# Altium schematic sheet MAIN_MCU_LORA.SchDoc(MAIN_MCU_LORA)
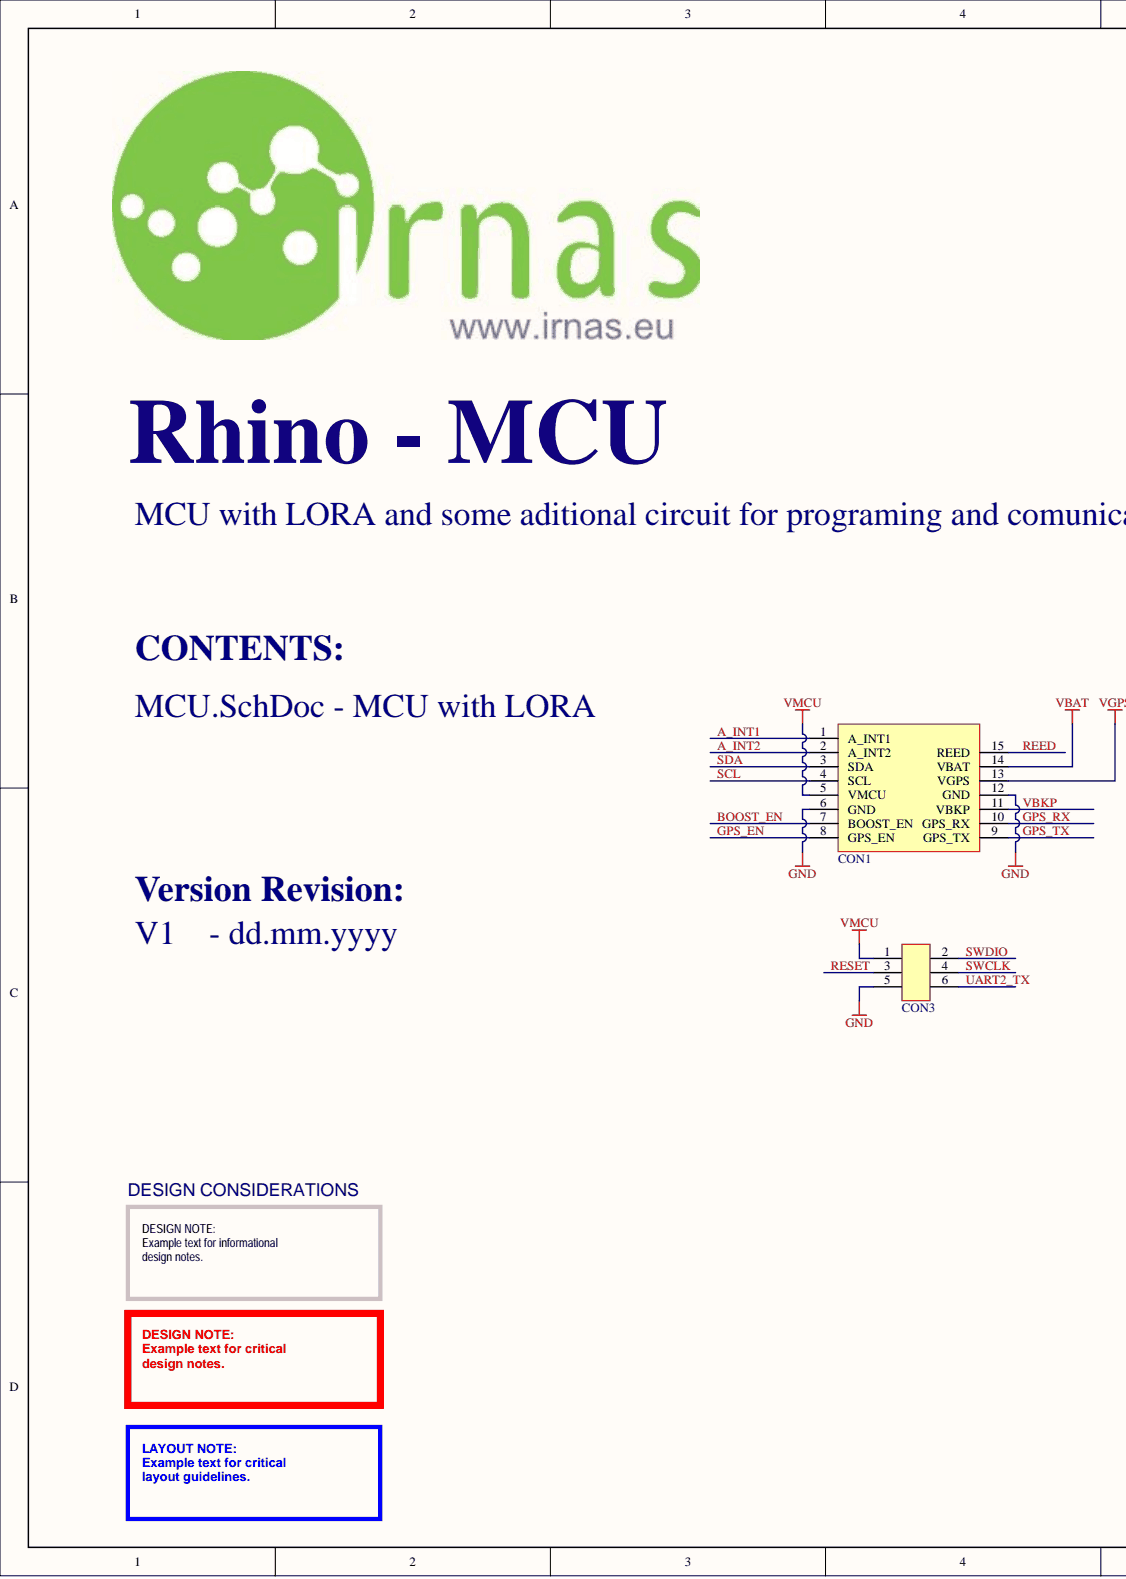
[diagram p0: MAIN_MCU_LORA.SchDoc, left]
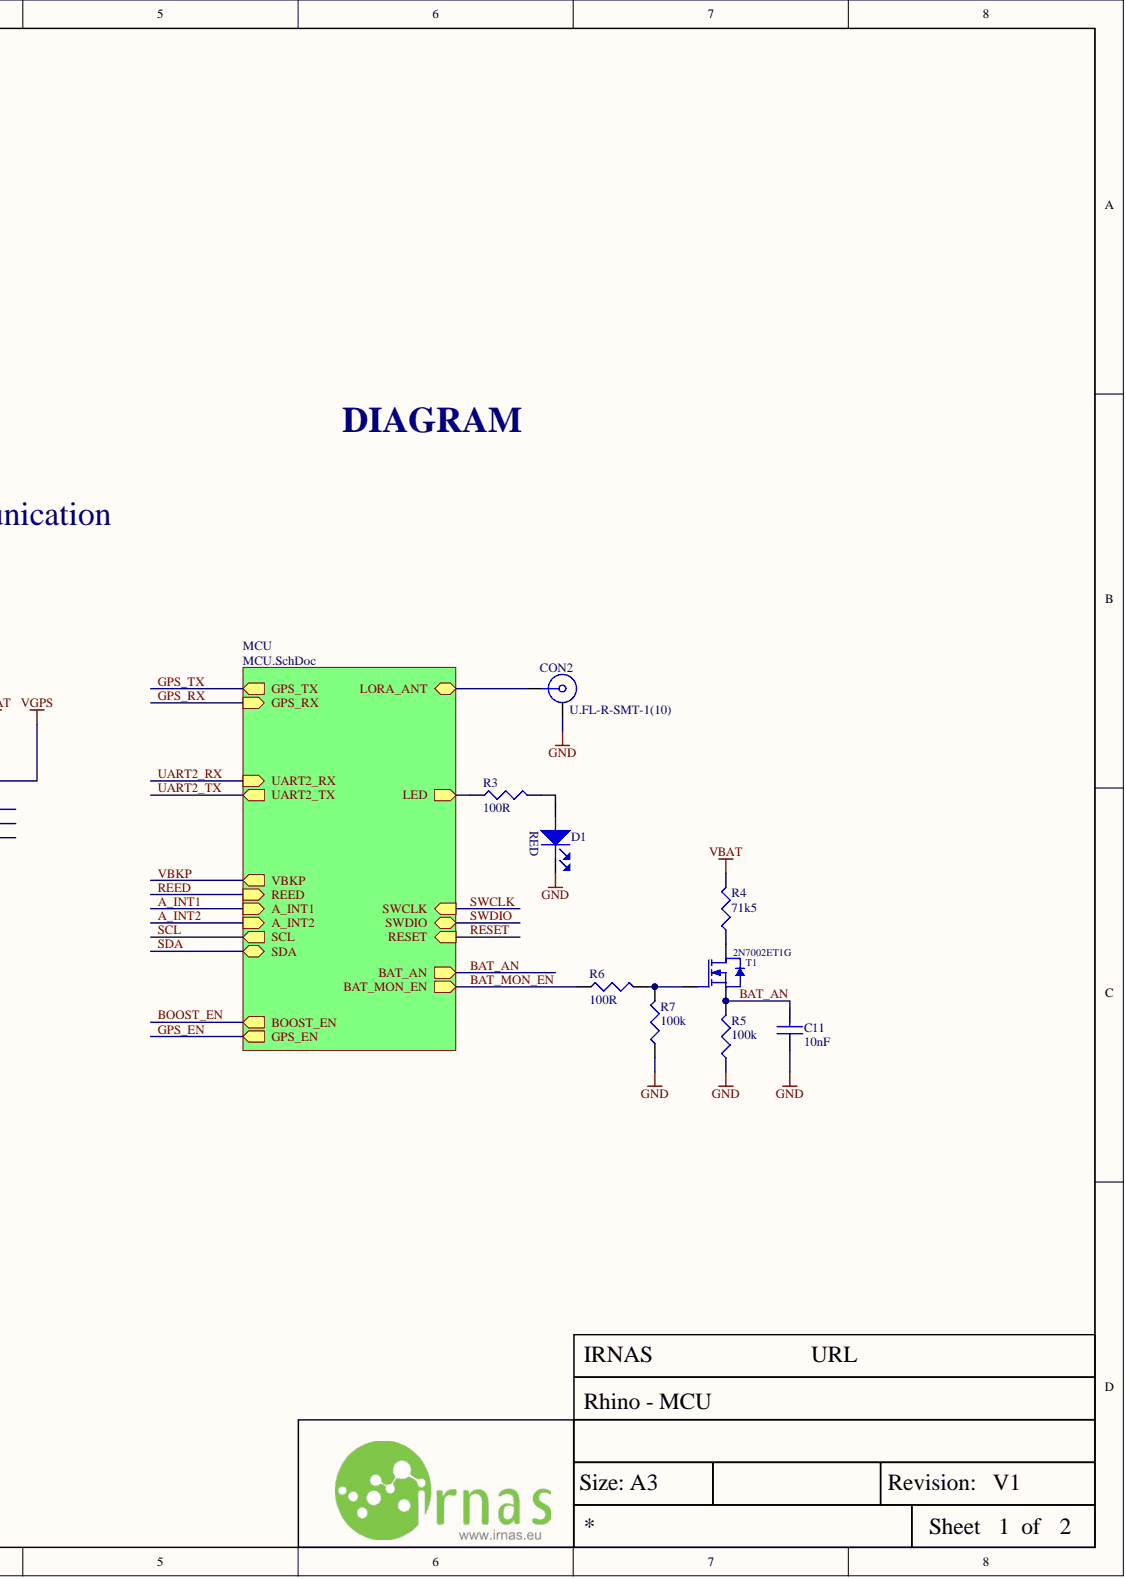
[diagram p1: MAIN_MCU_LORA.SchDoc, right]

## Parts
C11  10nF  [CAP0402]
CON1  Between PCB connection  [SmartParks_Rhino]  (value not drawn)
CON2  U.FL-R-SMT-1(10)  [ufl connector smd]
CON3  Tag connect  [TC2030-IDC-NL]  (value not drawn)  (no BOM)
D1  LED RED  [LED0603]  (value not drawn)
R3  100R  [RES0402]
R4  71k5  [RES0402]
R5  100k  [RES0402]
R6  100R  [RES0402]
R7  100k  [RES0402]
T1  2N7002ET1G  [SOT23-3AL]

## Nets
GND: C11.1 CON1.6(GND) CON1.12(GND) CON2.2 CON3.5 D1.1 R5.1 R7.1
VBAT: CON1.14(VBAT) R4.2
VGPS: CON1.13(VGPS)
VMCU: CON1.5(VMCU) CON3.1
A_INT1: CON1.1(A_INT1)
A_INT2: CON1.2(A_INT2)
BAT_AN: C11.2 R5.2 T1.2
BAT_MON_EN: R6.1
BOOST_EN: CON1.7(BOOST_EN)
GPS_EN: CON1.8(GPS_EN)
GPS_RX: CON1.10(GPS_RX)
GPS_TX: CON1.9(GPS_TX)
REED: CON1.15(REED)
RESET: CON3.3
SCL: CON1.4(SCL)
SDA: CON1.3(SDA)
SWCLK: CON3.4
SWDIO: CON3.2
UART2_TX: CON3.6
VBKP: CON1.11(VBKP)
NetCON2_1: CON2.1
NetD1_2: D1.2 R3.2
NetR3_1: R3.1
NetR4_1: R4.1 T1.3
NetR6_2: R6.2 R7.2 T1.1
UART2_RX:

## Library data of the parts
C11 = C - 10nF/0402: CAP CER 10000PF 16V X7R 0402; Manufacturer Part Number: GRM155R71C103KA01D; Manufacturer: Murata; IRNAS Part No.: /; Tolerance: 10%; Width: 0.02inch; Voltage Rating (DC): 16V; Voltage Rating: 16V; Voltage: 16V; Thickness: 0.022inch; Termination: SMD/SMT; Supplier Part Number 1: 1828864; Supplier 1: Farnell; Stock 1: 16478; REACH SVHC: No SVHC; Radiation Hardening: No; Pricing 1: 10=0.0216, 100=0.0151, 500=0.0104, 2500=0.0069, 5000=0.0056 (EUR); Packaging: Tape and Reel; Package Quantity: 1; Number of Pins: 2; Mount: Surface Mount; Min Operating Temperature: -55°C; Max Operating Temperature: 125°C; Library Name: Passive.SchLib; Length: 1mm; Lead Free: Lead Free; Height: 0.5mm; Dielectric: X7R; Depth: 0.5mm; ComponentLink6URL: https://datasheet.ciiva.com/7937/608985-7937614.pdf; ComponentLink6Description: https://datasheet.ciiva.com/7937/608985-7937614.pdf; ComponentLink5URL: https://datasheet.ciiva.com/22698/608985-22698415.pdf; ComponentLink5Description: https://datasheet.ciiva.com/22698/608985-22698415.pdf; ComponentLink4URL: https://datasheet.ciiva.com/18184/getdatasheetpartid-178863-18184649.pdf; ComponentLink4Description: https://datasheet.ciiva.com/18184/getdatasheetpartid-178863-18184649.pdf; ComponentLink3URL: https://datasheet.ciiva.com/129/product-129776.pdf; ComponentLink3Description: https://datasheet.ciiva.com/129/product-129776.pdf; ComponentLink2URL: https://datasheet.ciiva.com/129/partnumbering-e-01-129775.pdf; ComponentLink2Description: https://datasheet.ciiva.com/129/partnumbering-e-01-129775.pdf; ComponentLink1URL: https://datasheet.ciiva.com/129/partnumbering-e-01-129774.pdf; ComponentLink1Description: https://datasheet.ciiva.com/129/partnumbering-e-01-129774.pdf; Component Kind: Standard; Case Code (Metric): 1005; Case Code (Imperial): 0402; Case/Package: 0402; Capacitance: 10nF
CON1 = SmartParks_Rhino: Library Name: Connectors.SchLib; Description: Between PCB connection; Component Kind: Standard
CON2 = U.FL-R-SMT-1(10): RF / Coaxial Connector, U.FL Coaxial, Straight Jack, Surface Mount Vertical, 50 ohm, Brass; Manufacturer: Hirose; Shield Termination: Solder; Other Names: H11891TR  Q5400621  U.FL-R-SMT-1 10  U.FL-R-SMT-1(10)-ND  UFLRSMT110; Online Catalog: UMCC (Ultra Miniature Coax Connectors), U.FL Series; Impedance: 50 Ohm; Frequency - Max: 6GHz; Featured Product: U.FL Series Connectors; Dielectric Material: Liquid Crystal Polymer (LCP); Connector Type: Receptacle, Male Pin; Connector Style: U.FL, Ultra Miniature Coaxial; Center Contact Plating: Gold; Body Material: Phosphor Bronze; Body Finish: Silver; Voltage Rating: -; Supplier Part Number 1: 1688077; Supplier 1: Farnell; Stock 1: 0; Standard Package: 2,500; Series: U.FL; Packaging: Tape & Reel (TR); Operating Temperature: -40°C ~ 90°C; Mounting Type: Surface Mount; Manufacturer Part Number: U.FL-R-SMT-1(10); Library Name: Connectors.SchLib; Ingress Protection: -; Housing Color: Beige; Features: -; Fastening Type: Snap-On; Family: Coaxial Connectors (RF); Contact Termination: Solder; ComponentLink3URL: http://www.farnell.com/datasheets/1947917.pdf; ComponentLink3Description: http://www.farnell.com/datasheets/1947917.pdf; ComponentLink2URL: http://uk.rs-online.com/webdocs/1363/0900766b81363563.pdf; ComponentLink2Description: http://uk.rs-online.com/webdocs/1363/0900766b81363563.pdf; ComponentLink1URL: http://www.farnell.com/datasheets/91524.pdf; ComponentLink1Description: http://www.farnell.com/datasheets/91524.pdf; Component Kind: Standard; Center Contact Material: Brass; Category: Connectors, Interconnects; Cable Group: -
CON3 = tag connecot 6 pin: Library Name: Connectors.SchLib
D1 = LED - LED RED: BROADCOM LIMITED - HSMS-C190 - LED, RED, 10MCD, 626NM; Wavelength Typ: 626; Viewing Angle: 170°; SVHC: No SVHC (17-Dec-2015)<!--FANDB--><div class="features-and-benefits-container"><div class="features-and-benefits-description"><span class="features-benefits-value">The HSMS-C190 is a HER SMD Chip LED with untinted/diffused lens, made of GaP. It is designed in an industry standard package 1.6 x 0.8mm footprint with 0.8mm profile. It is compatible with IR reflow solder processes. The small size and wide viewing angle make this LED prime choices for backlighting applications and front panel illumination especially where space is a premium.</span></div><div class="features-and-benefits-list"><ul class="features-and-benefits-list-list"><li class="features-and-benefits-list-item">Small size</li><li class="features-and-benefits-list-item">Industry standard footprint</li><li class="features-and-benefits-list-item">Compatible with IR solder</li><li class="features-and-benefits-list-item">Diffused optics</li><li class="features-and-benefits-list-item">-40 to 85; Luminous Intensity: 10; Lens Shape: Rectangular; LED Mounting: SMD; Forward Voltage: 2.1; Forward Current If: 20; Supplier Part Number 1: 2497356; Supplier 1: Farnell; Stock 1: 7534; RoHS: YES; Product Range: -; Pricing 1: 1=0.361, 10=0.256, 50=0.235, 100=0.106, 200=0.101 (GBP); Packaging: Cut Tape; MSL: -; Manufacturer Part Number: HSMS-C190; Manufacturer: BROADCOM LIMITED; Library Name: Active.SchLib; LED Colour: Red; ComponentLink3URL: http://www.farnell.com/datasheets/2165483.pdf; ComponentLink3Description: http://www.farnell.com/datasheets/2165483.pdf; ComponentLink2URL: http://www.farnell.com/datasheets/1681524.pdf; ComponentLink2Description: http://www.farnell.com/datasheets/1681524.pdf; ComponentLink1URL: http://www.farnell.com/datasheets/313031.pdf; ComponentLink1Description: http://www.farnell.com/datasheets/313031.pdf; Component Kind: Standard; Bulb Size: -; Automotive Qualification Standard: -
R3 R6 = R - 100R/0402: MULTICOMP         MCMR04X1000FTL            SMD Chip Resistor, Ceramic, MCMR Series, 100 ohm, 50 V, 0402 [1005 Metric], 62.5 mW,  1%; IRNAS Part No.: /; Voltage Rating (DC): 50V; Temperature Coefficient: 100ppm/°C; Power Rating: 62.5mW; Voltage Rating: 50V; Tolerance: 1%; Supplier Part Number 1: 2072515; Supplier 1: Farnell; Stock 1: 62365; Resistance: 100R; REACH SVHC: No SVHC; Pricing 1: 10=0.0071, 100=0.0042, 500=0.003, 2500=0.0024, 5000=0.0019 (GBP); Packaging: Cut Tape; Manufacturer Part Number: MCMR04X1000FTL; Manufacturer: Multicomp; Library Name: Passive.SchLib; Composition: Ceramic; ComponentLink2URL: https://datasheet.ciiva.com/22708/1716705-22708156.pdf; ComponentLink2Description: https://datasheet.ciiva.com/22708/1716705-22708156.pdf; ComponentLink1URL: https://datasheet.ciiva.com/28692/1716705-28692087.pdf; ComponentLink1Description: https://datasheet.ciiva.com/28692/1716705-28692087.pdf; Component Kind: Standard; Case Code (Metric): 1005; Case Code (Imperial): 0402; Case/Package: 0402
R4 = R - 71k5/0402: MULTICOMP         MCMR04X7152FTL            Surface Mount Chip Resistor, Ceramic, MCMR Series, 71.5 kohm, 62.5 mW,  1%, 50 V; IRNAS Part No.: /; Voltage Rating (DC): 50V; Temperature Coefficient: 100ppm/°C; Resistance: 71.5kR; Power Rating: 62.5mW; Voltage Rating: 50V; Tolerance: 1%; Supplier Part Number 1: 2073227; Supplier 1: Farnell; Stock 1: 34671; REACH SVHC: No SVHC; Pricing 1: 10=0.0054, 100=0.0048, 500=0.0041, 2500=0.0029, 5000=0.0023, 10000=0.002, 50000=0.0015 (EUR); Packaging: Cut Tape; Manufacturer Part Number: MCMR04X7152FTL; Manufacturer: Multicomp; Library Name: Passive.SchLib; Composition: Ceramic; ComponentLink1URL: https://datasheet.ciiva.com/28672/1716706-28672249.pdf; ComponentLink1Description: https://datasheet.ciiva.com/28672/1716706-28672249.pdf; Component Kind: Standard; Case Code (Metric): 1005; Case Code (Imperial): 0402; Case/Package: 0402
R5 R7 = R - 100k/0402: MULTICOMP   MCWR04X1003FTL   RES, THICK FILM, 100KOHM, 1%, 0.0625W; IRNAS Part No.: I-100013; Voltage Rating (DC): 50V; Temperature Coefficient: 100ppm/°C; Resistance: 100kR; Power Rating: 62.5mW; Voltage Rating: 50V; Tolerance: 1%; Supplier Part Number 1: 2447094; Supplier 1: Farnell; Stock 1: 161188; REACH SVHC: No SVHC; Pricing 1: 10=0.0029, 100=0.0017, 500=0.0012, 2500=0.001, 5000=0.0008 (EUR); Packaging: Cut Tape; Mount: Surface Mount; Manufacturer Part Number: MCWR04X1003FTL; Manufacturer: Multicomp; Library Name: Passive.SchLib; Composition: Thick Film; ComponentLink1URL: https://datasheet.ciiva.com/46386/1849611-46386524.pdf; ComponentLink1Description: https://datasheet.ciiva.com/46386/1849611-46386524.pdf; Component Kind: Standard; Case Code (Metric): 1005; Case Code (Imperial): 0402; Case/Package: 0402
T1 = T_M - 2N7002ET1G: MOSFET Transistor, N Channel, 310 mA, 60 V, 0.86 ohm, 10 V, 1 V; Velikost / Size: SOT23; Value: BSS123; Opis / Description: MOSFET BSS123       /N-Channel; Komp / Device: SMD; FIT: 0.44; ELRAD Id sifra / ELRAD Id No: 597778; Supplier Part Number 2: 863-2N7002ET1G; Supplier Part Number 1: 2317616; Supplier 2: Mouser; Supplier 1: Farnell; Signal Integrity: BSS138W; Library Name: Active.SchLib; Date: 11.07.2018; ComponentLINK2URL: http://www.onsemi.com/pub/Collateral/BSS123-D.PDF; ComponentLINK2Description: Datasheet www; ComponentLink1Description: Datasheet; ComponentLINK1Description: Datasheet; Component Kind: Standard; ComponentLink1URL: C:\ELRADSVN\Altium_Library\Libraries\Active\Datasheet\2N7002ET1G.pdf; ComponentLINK1URL: c:\ELRADSVN\Altium_Library\Libraries\Active\Datasheet\BSS123.pdf; Author: Ciril Golob
